# Altium schematic sheet ETH_3PORT.SchDoc
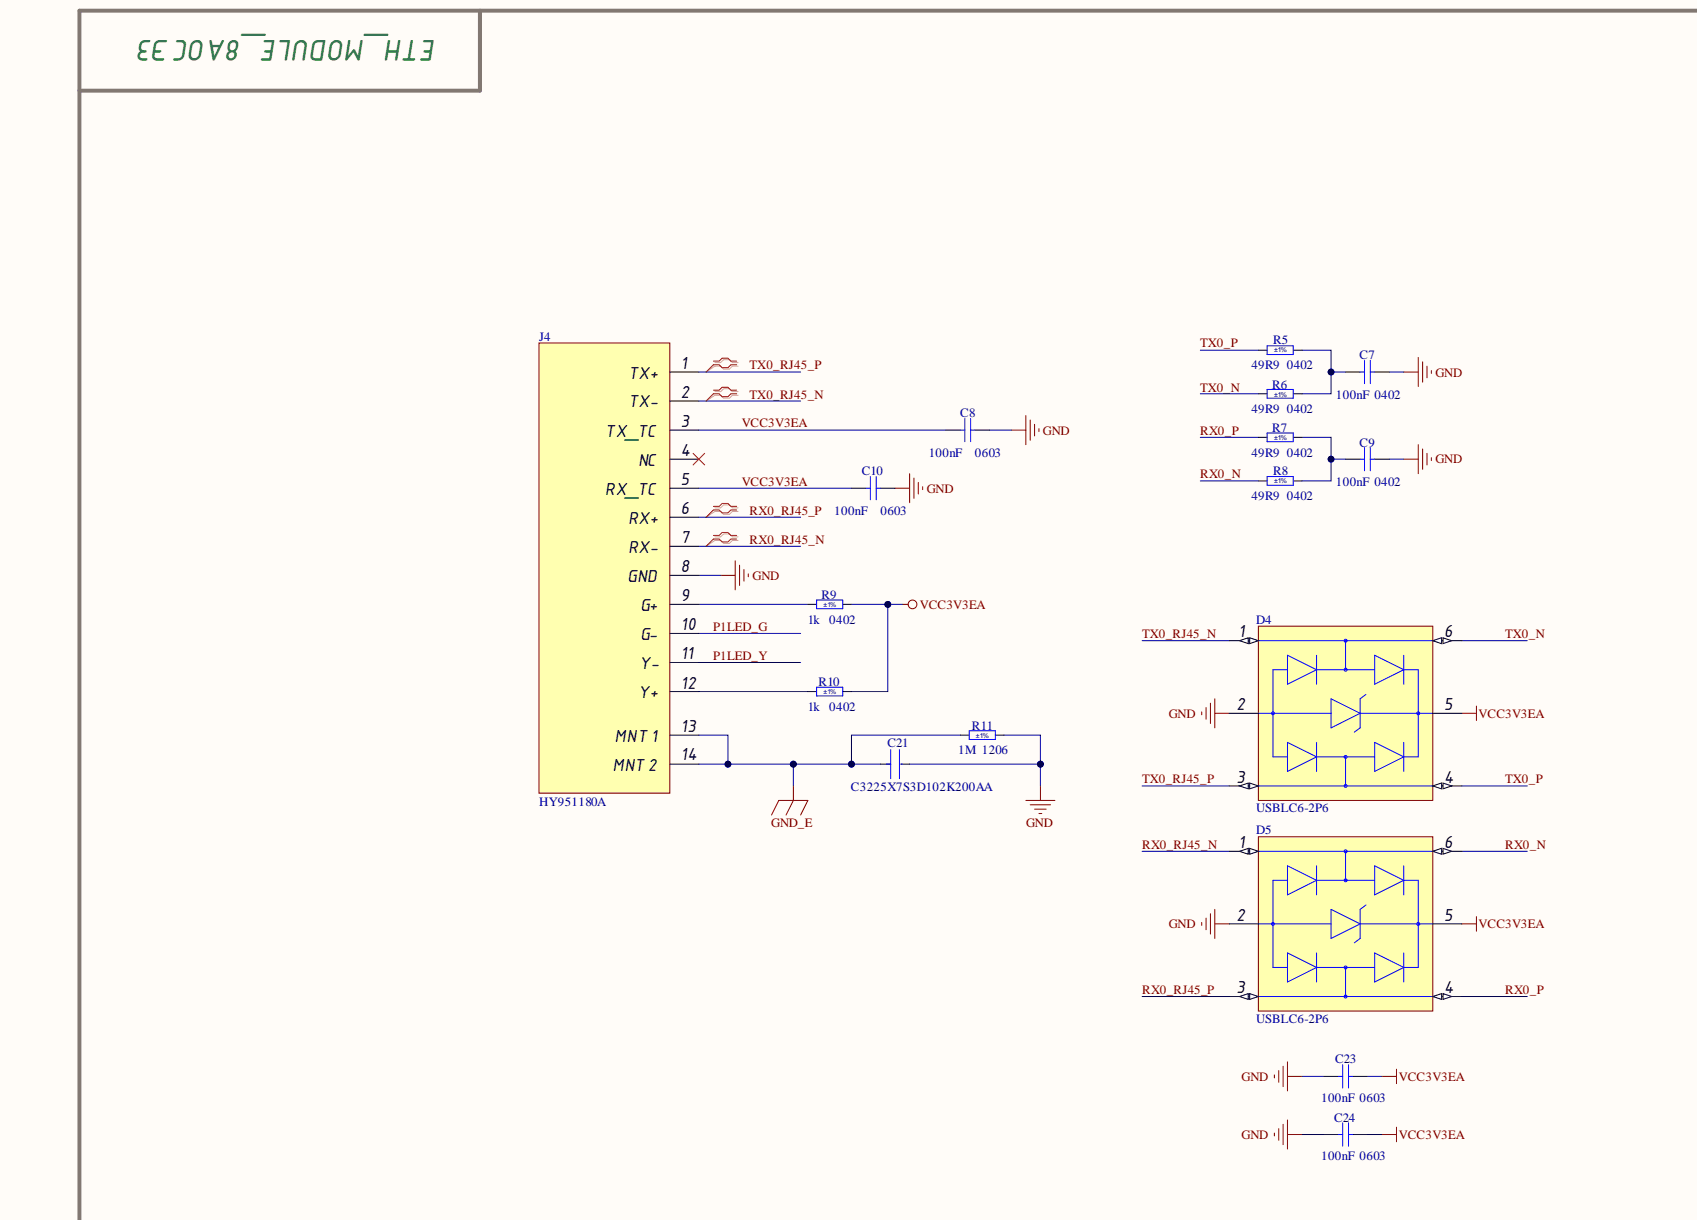
[diagram p0: ETH_3PORT.SchDoc, top left]
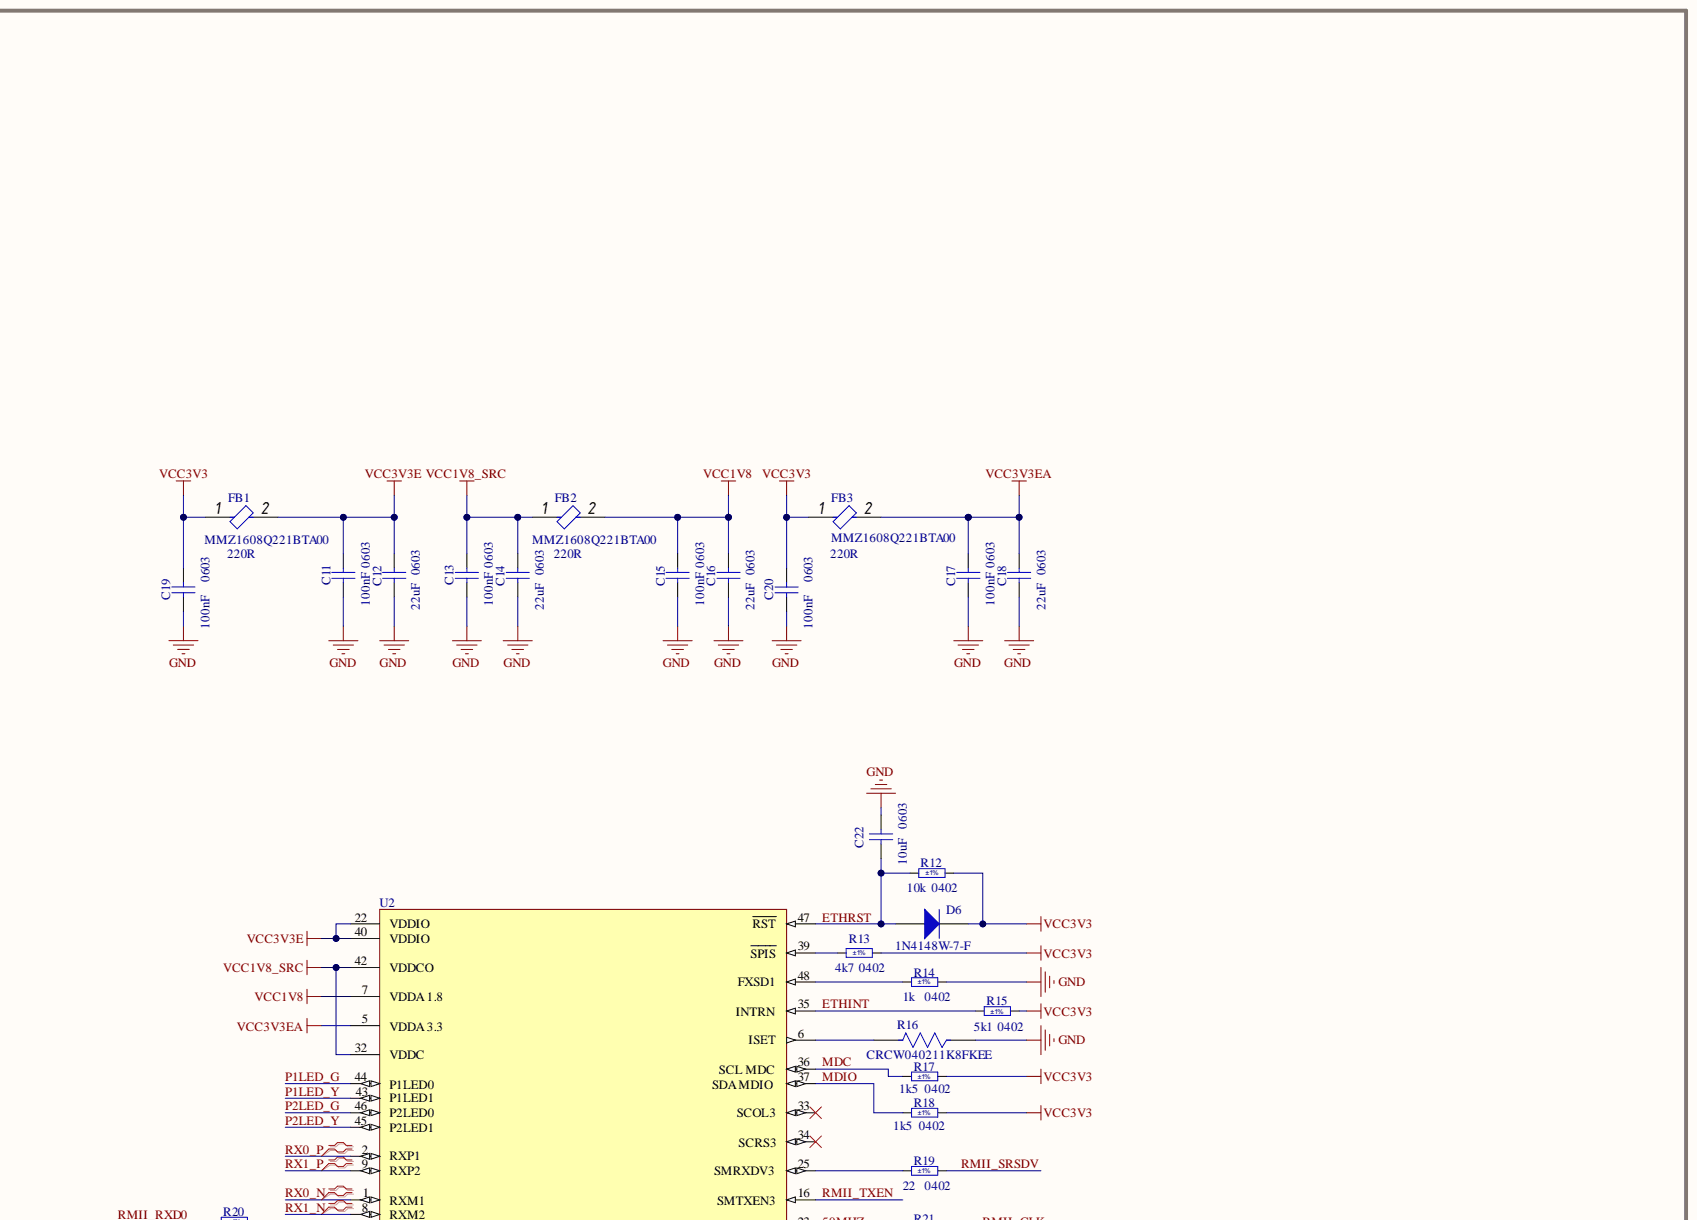
[diagram p1: ETH_3PORT.SchDoc, top right]
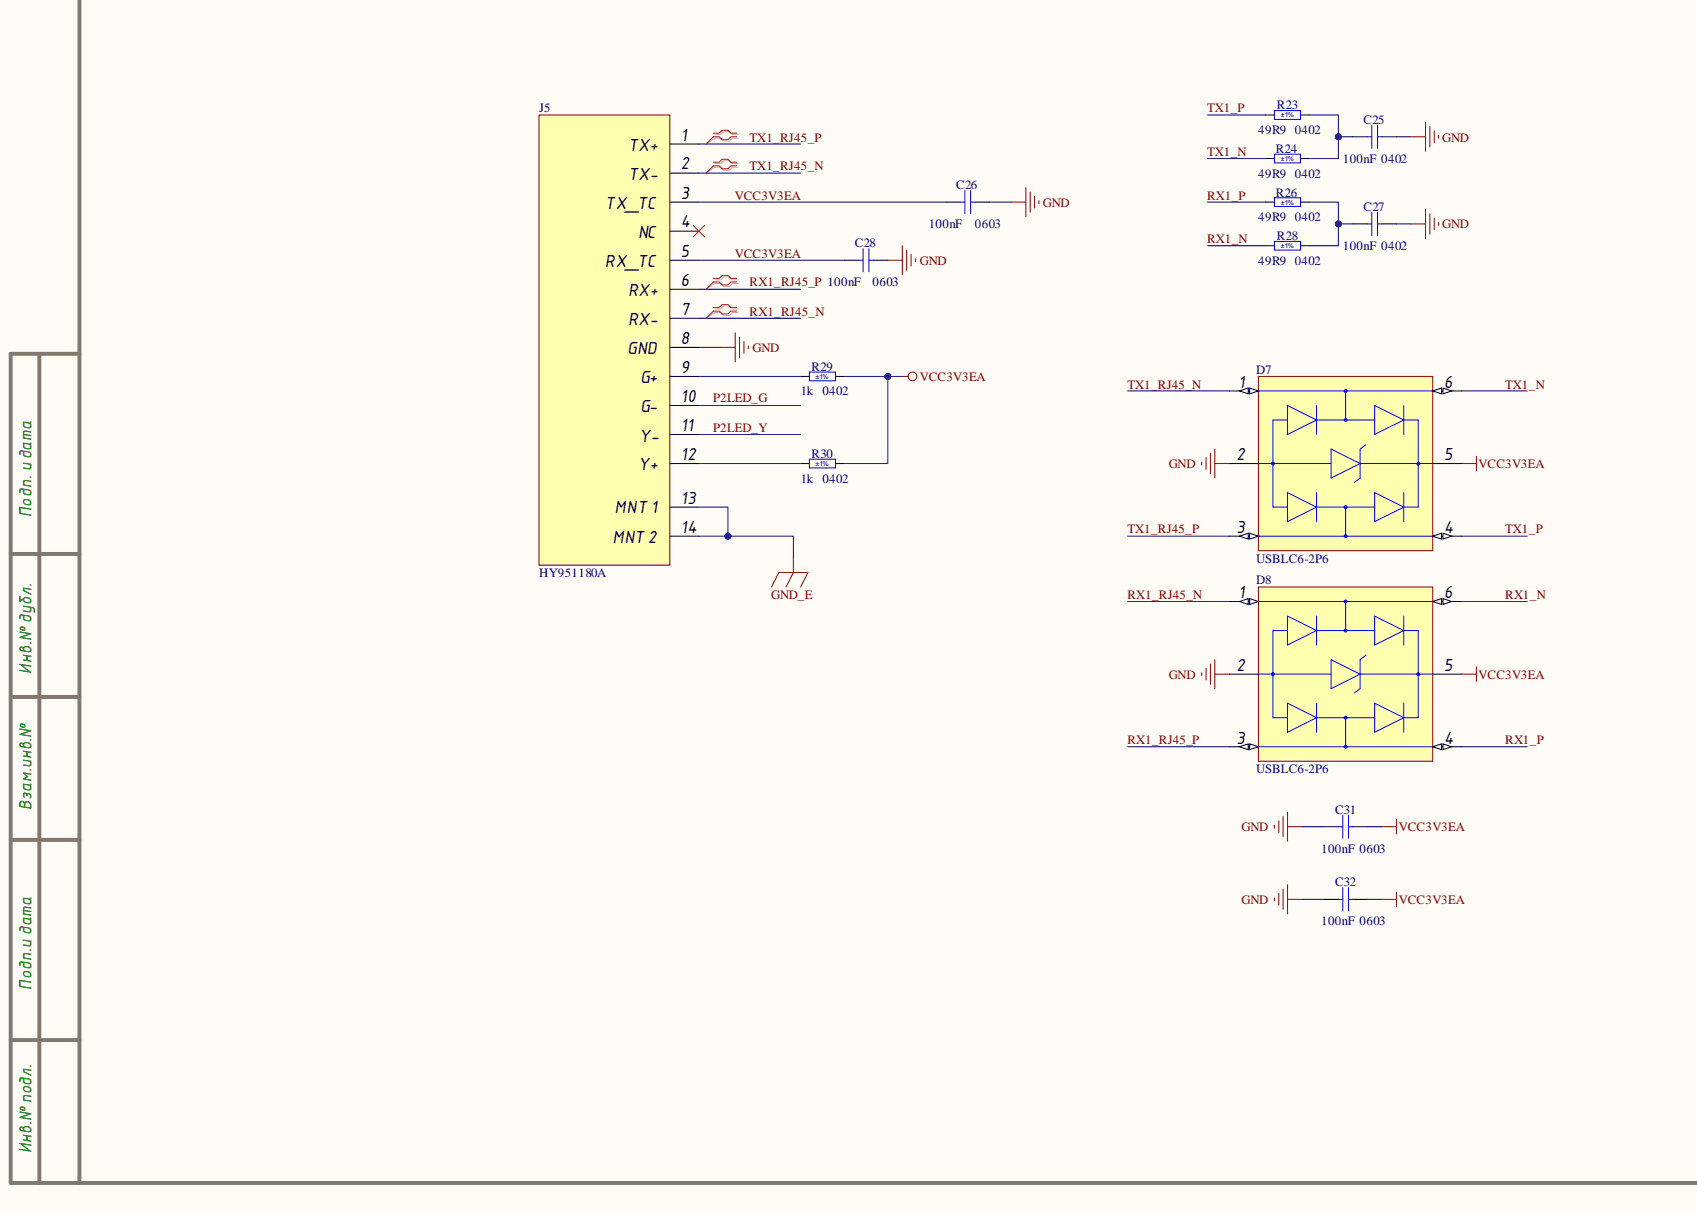
[diagram p2: ETH_3PORT.SchDoc, bottom left]
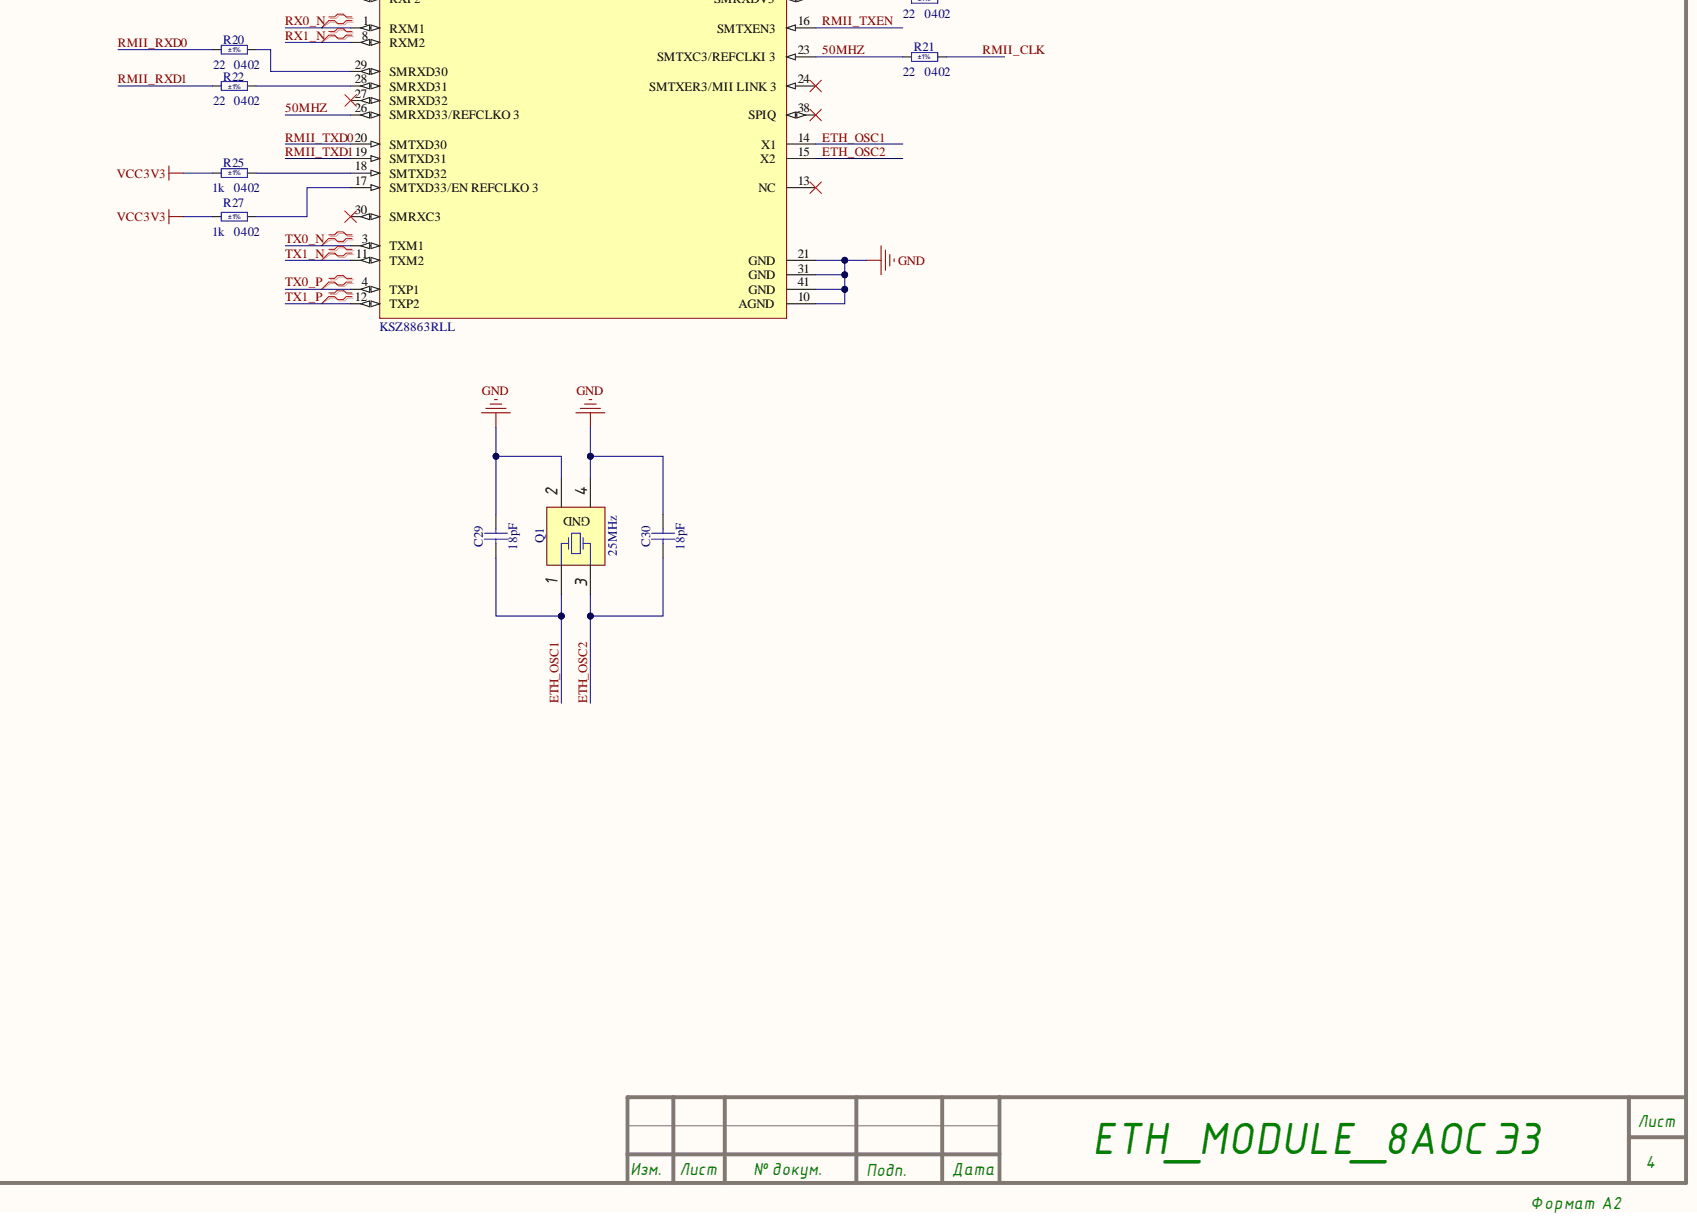
[diagram p3: ETH_3PORT.SchDoc, bottom right]

## Parts
C7  100nF  Case: 0402  [CAPC1005X55N]
C8  100nF  Case: 0603  [CAPC1608X90N]
C9  100nF  Case: 0402  [CAPC1005X55N]
C10  100nF  Case: 0603  [CAPC1608X90N]
C11  100nF  [CAPC1608X87N]
C12  22uF  Case: 0603  [CAPC1608X90N]
C13  100nF  Case: 0603  [CAPC1608X87N]
C14  22uF  Case: 0603  [CAPC1608X90N]
C15  100nF  Case: 0603  [CAPC1608X87N]
C16  22uF  Case: 0603  [CAPC1608X90N]
C17  100nF  Case: 0603  [CAPC1608X87N]
C18  22uF  Case: 0603  [CAPC1608X90N]
C19  100nF  Case: 0603  [CAPC1608X87N]
C20  100nF  Case: 0603  [CAPC1608X87N]
C21  C3225X7S3D102K200AA  [FP-C3225-200-0_4-IPC_B]
C22  10uF  Case: 0603  [CAPC1608X80N]
C23  100nF  Case: 0603  [CAPC1608X87N]
C24  100nF  Case: 0603  [CAPC1608X87N]
C25  100nF  Case: 0402  [CAPC1005X55N]
C26  100nF  Case: 0603  [CAPC1608X90N]
C27  100nF  Case: 0402  [CAPC1005X55N]
C28  100nF  Case: 0603  [CAPC1608X90N]
C29  18pF  [CAPC1608X87N]
C30  18pF  [CAPC1608X87N]
C31  100nF  Case: 0603  [CAPC1608X87N]
C32  100nF  Case: 0603  [CAPC1608X87N]
D4  USBLC6-2P6  [FP-SOT-666-MFG]
D5  USBLC6-2P6  [FP-SOT-666-MFG]
D6  1N4148W-7-F  [DIOD-SOD123-2_V]
D7  USBLC6-2P6  [FP-SOT-666-MFG]
D8  USBLC6-2P6  [FP-SOT-666-MFG]
FB1  MMZ1608Q221BTA00  Impedance: 220R  [FP-MMZ1608-0_8-IPC_C]
FB2  MMZ1608Q221BTA00  Impedance: 220R  [FP-MMZ1608-0_8-IPC_C]
FB3  MMZ1608Q221BTA00  Impedance: 220R  [FP-MMZ1608-0_8-IPC_C]
J4  HY951180A  [HY951180A]
J5  HY951180A  [HY951180A]
Q1  25MHz  [XTAL_EPSON_TSX-3225]
R5  49R9  Case: 0402  Tolerance: ±1%  [RESC1005X40N]
R6  49R9  Case: 0402  Tolerance: ±1%  [RESC1005X40N]
R7  49R9  Case: 0402  Tolerance: ±1%  [RESC1005X40N]
R8  49R9  Case: 0402  Tolerance: ±1%  [RESC1005X40N]
R9  1k  Case: 0402  Tolerance: ±1%  [RESC1005X40N]
R10  1k  Case: 0402  Tolerance: ±1%  [RESC1005X40N]
R11  1M  Case: 1206  Tolerance: ±1%  [RESC3216X65N]
R12  10k  Case: 0402  Tolerance: ±1%  [RESC1005X40N]
R13  4k7  Case: 0402  Tolerance: ±1%  [RESC1005X40N]
R14  1k  Case: 0402  Tolerance: ±1%  [RESC1005X40N]
R15  5k1  Case: 0402  Tolerance: ±1%  [RESC1005X40N]
R16  CRCW040211K8FKEE  [FP-CRCW0402-e3-IPC_B]
R17  1k5  Case: 0402  Tolerance: ±1%  [RESC1005X40N]
R18  1k5  Case: 0402  Tolerance: ±1%  [RESC1005X40N]
R19  22  Case: 0402  Tolerance: ±1%  [RESC1005X40N]
R20  22  Case: 0402  Tolerance: ±1%  [RESC1005X40N]
R21  22  Case: 0402  Tolerance: ±1%  [RESC1005X40N]
R22  22  Case: 0402  Tolerance: ±1%  [RESC1005X40N]
R23  49R9  Case: 0402  Tolerance: ±1%  [RESC1005X40N]
R24  49R9  Case: 0402  Tolerance: ±1%  [RESC1005X40N]
R25  1k  Case: 0402  Tolerance: ±1%  [RESC1005X40N]
R26  49R9  Case: 0402  Tolerance: ±1%  [RESC1005X40N]
R27  1k  Case: 0402  Tolerance: ±1%  [RESC1005X40N]
R28  49R9  Case: 0402  Tolerance: ±1%  [RESC1005X40N]
R29  1k  Case: 0402  Tolerance: ±1%  [RESC1005X40N]
R30  1k  Case: 0402  Tolerance: ±1%  [RESC1005X40N]
U2  KSZ8863RLL  [FP-LQFP7x7-48LD-PL-1-IPC_A]

## Nets
GND: C7.2 C8.2 C9.2 C10.2 C11.1 C12.1 C13.1 C14.1 C15.1 C16.1 C17.1 C18.1 C19.1 C20.1 C21.2 C22.1 C23.1 C24.1 C25.2 C26.2 C27.2 C28.2 C29.2 C30.2 C31.1 C32.1 D4.2 D5.2 D7.2 D8.2 J4.8(GND) J5.8(GND) Q1.2 Q1.4 R11.2 R14.2 R16.1 U2.10(AGND) U2.21(GND) U2.31(GND) U2.41(GND)
GND_E: C21.1 J4.13(MNT_1) J4.14(MNT_2) J5.13(MNT_1) J5.14(MNT_2) R11.1
VCC1V8: C15.2 C16.2 FB2.2 U2.7(VDDA_1.8)
VCC1V8_SRC: C13.2 C14.2 FB2.1 U2.32(VDDC) U2.42(VDDCO)
VCC3V3: C19.2 C20.2 D6.2 FB1.1 FB3.1 R12.2 R13.2 R15.2 R17.2 R18.2 R25.1 R27.1
VCC3V3E: C11.2 C12.2 FB1.2 U2.22(VDDIO) U2.40(VDDIO)
50MHZ: R21.1 U2.23(SMTXC3/REFCLKI_3) U2.26(SMRXD33/REFCLKO_3)
ETH_OSC1: C29.1 Q1.1 U2.14(X1)
ETH_OSC2: C30.1 Q1.3 U2.15(X2)
ETHINT: R15.1 U2.35(INTRN)
ETHRST: C22.2 D6.1 R12.1 U2.47(RST)
MDC: R17.1 U2.36(SCL_MDC)
MDIO: R18.1 U2.37(SDA_MDIO)
P1LED_G: J4.10(G-) U2.44(P1LED0)
P1LED_Y: J4.11(Y-) U2.43(P1LED1)
P2LED_G: J5.10(G-) U2.46(P2LED0)
P2LED_Y: J5.11(Y-) U2.45(P2LED1)
RMII_CLK: R21.2
RMII_RXD0: R20.1
RMII_RXD1: R22.1
RMII_SRSDV: R19.2
RMII_TXD0: U2.20(SMTXD30)
RMII_TXD1: U2.19(SMTXD31)
RMII_TXEN: U2.16(SMTXEN3)
RX0_N: D5.6 R8.1 U2.1(RXM1)
RX0_P: D5.4 R7.1 U2.2(RXP1)
RX0_RJ45_N: D5.1 J4.7(RX-)
RX0_RJ45_P: D5.3 J4.6(RX+)
RX1_N: D8.6 R28.1 U2.8(RXM2)
RX1_P: D8.4 R26.1 U2.9(RXP2)
RX1_RJ45_N: D8.1 J5.7(RX-)
RX1_RJ45_P: D8.3 J5.6(RX+)
TX0_N: D4.6 R6.1 U2.3(TXM1)
TX0_P: D4.4 R5.1 U2.4(TXP1)
TX0_RJ45_N: D4.1 J4.2(TX-)
TX0_RJ45_P: D4.3 J4.1(TX+)
TX1_N: D7.6 R24.1 U2.11(TXM2)
TX1_P: D7.4 R23.1 U2.12(TXP2)
TX1_RJ45_N: D7.1 J5.2(TX-)
TX1_RJ45_P: D7.3 J5.1(TX+)
VCC3V3EA: C8.1 C10.1 C17.2 C18.2 C23.2 C24.2 C26.1 C28.1 C31.2 C32.2 D4.5 D5.5 D7.5 D8.5 FB3.2 J4.3(TX_TC) J4.5(RX_TC) J5.3(TX_TC) J5.5(RX_TC) R9.2 R10.2 R29.2 R30.2 U2.5(VDDA_3.3)
NetC7_1: C7.1 R5.2 R6.2
NetC9_1: C9.1 R7.2 R8.2
NetC25_1: C25.1 R23.2 R24.2
NetC27_1: C27.1 R26.2 R28.2
NetJ4_9: J4.9(G+) R9.1
NetJ4_12: J4.12(Y+) R10.1
NetJ5_9: J5.9(G+) R29.1
NetJ5_12: J5.12(Y+) R30.1
NetR13_1: R13.1 U2.39(SPIS)
NetR14_1: R14.1 U2.48(FXSD1)
NetR16_2: R16.2 U2.6(ISET)
NetR19_1: R19.1 U2.25(SMRXDV3)
NetR20_2: R20.2 U2.29(SMRXD30)
NetR22_2: R22.2 U2.28(SMRXD31)
NetR25_2: R25.2 U2.18(SMTXD32)
NetR27_2: R27.2 U2.17(SMTXD33/EN_REFCLKO_3)

Not connected: J4 4(NC); J5 4(NC); U2 13(NC) 24(SMTXER3/MII_LINK_3) 27(SMRXD32) 30(SMRXC3) 33(SCOL3) 34(SCRS3) 38(SPIQ)

## Library data of the parts
C7 C9 C25 C27 = CC0402_100NF_16V_10%_X5R: Non-polarised capacitor; Manufacturer: GENERIC; Manufacturer1 Part Number: GRM155R61C104KA88D; Part Description: SMD Multilayer Chip Ceramic Capacitor; Database Table Name: Capacitors SMD; TC: X5R; Tolerance: ±10%; Voltage: 16V; Component Kind: Standard; Component Type: Standard; CreateDate: 10.12.2013; HelpURL: \\cern.ch\dfs\Applications\Altium\Datasheets\CC0402_X5R_MURATA_GRM.pdf; LatestRevisionDate: 10.12.2013; Library Name: Capacitors.DbLib; Manufacturer1 ComponentHeight: 0.55mm; Manufacturer1 Example: MURATA; Manufacturer Part Number: CC0402_100NF_16V_10%_X5R; Mounted: Yes; Part Number: CC0402_100NF_16V_10%_X5R; Pin Count: 2; Sense: No; SMD: Yes; Socket: No; Status: None; Value: 100nF; Author: CERN DEM JLC
C8 C10 C26 C28 = CC0603_100NF_25V_20%_X5R_TDK_CGA3E2X5R1E104M080: Non-polarised capacitor; Manufacturer: TDK; Part Description: SMD Multilayer Chip Ceramic Capacitor (Automotive Grade); Database Table Name: Capacitors SMD; TC: X5R; Tolerance: ±20%; Voltage: 25V; Component Kind: Standard; Component Type: Standard; ComponentHeight: 0.9mm; CreateDate: 01.07.2020; HelpURL: \\cern.ch\dfs\Applications\Altium\Datasheets\CC0603_X5R_TDK_CGA(Up to 75V).pdf; LatestRevisionDate: 01.07.2020; Library Name: Capacitors.DbLib; Manufacturer Part Number: CGA3E2X5R1E104M080; Mounted: Yes; Part Number: CC0603_100NF_25V_20%_X5R_TDK_CGA3E2X5R1E104M080; Pin Count: 2; Sense: No; SMD: Yes; Socket: No; Status: Not Recommended; Value: 100nF; Author: CERN DEM BC
C11 C13 C15 C17 C19 C20 = CC0603_100NF_50V_10%_X5R: Non-polarised capacitor; Manufacturer: GENERIC; Manufacturer1 Part Number: C1608X5R1H104K080; Part Description: SMD Multilayer Chip Ceramic Capacitor; Database Table Name: Capacitors SMD; TC: X5R; Tolerance: ±10%; Voltage: 50V; Component Kind: Standard; Component Type: Standard; CreateDate: 08.01.2016; HelpURL: \\cern.ch\dfs\Applications\Altium\Datasheets\CC0603_X5R_TDK_C.pdf; LatestRevisionDate: 08.01.2016; Library Name: Capacitors.DbLib; Manufacturer1 ComponentHeight: 0.9mm; Manufacturer1 Example: TDK; Manufacturer Part Number: CC0603_100NF_50V_10%_X5R; Mounted: Yes; Part Number: CC0603_100NF_50V_10%_X5R; Pin Count: 2; Sense: No; SMD: Yes; Socket: No; Status: None; Value: 100nF; Author: CERN DEM MR
  C11: Case: 0603
C12 C14 C16 C18 = CC0603_22UF_6.3V_20%_X5R: Non-polarised capacitor; Manufacturer: GENERIC; Manufacturer1 Part Number: C1608X5R0J226M080; Part Description: SMD Multilayer Chip Ceramic Capacitor; Database Table Name: Capacitors SMD; TC: X5R; Tolerance: ±20%; Voltage: 6.3V; Component Kind: Standard; Component Type: Standard; CreateDate: 16.12.2013; HelpURL: \\cern.ch\dfs\Applications\Altium\Datasheets\CC0603_X5R_TDK_C.pdf; LatestRevisionDate: 03.12.2020; Library Name: Capacitors.DbLib; Manufacturer1 ComponentHeight: 0.9mm; Manufacturer1 Example: TDK; Manufacturer Part Number: CC0603_22UF_6.3V_20%_X5R; Mounted: Yes; Part Number: CC0603_22UF_6.3V_20%_X5R; Pin Count: 2; Sense: No; SMD: Yes; Socket: No; Status: None; Value: 22uF; Author: CERN DEM JLC
C21 = CMP-08246-003296-1: Multilayer Ceramic Capacitors 1nF ±10% 2000V X7S SMD 1210; Voltage Rating: 2kV; Capacitance: 1nF; Case Code (Imperial): 1210; Case Code (Metric): 3225; Case/Package: 1210; Component Kind: Standard; Depth: 2.5mm; Dielectric: X7S; Length: 0.126inch; Library Name: Altium Content Vault; Max Operating Temperature: 125°C; Min Operating Temperature: -55°C; Mount: Surface Mount; Package Quantity: 1; Packaging: Tape and Reel; REACH SVHC: No SVHC; Resistor Type: Ceramic; RoHS Compliant: Yes; Series: C; Termination: SMD/SMT; Thickness: 0.087inch; Tolerance: 10%; Voltage Rating (DC): 2kV; Weight: 0.000571oz; Width: 0.098inch
C22 = CC0603_10UF_10V_10%_X5R: Non-polarised capacitor; Manufacturer: GENERIC; Manufacturer1 Part Number: GRM188R61A106KE69D; Part Description: SMD Multilayer Chip Ceramic Capacitor; Database Table Name: Capacitors SMD; TC: X5R; Tolerance: ±10%; Voltage: 10V; Component Kind: Standard; Component Type: Standard; CreateDate: 31.01.2017; HelpURL: \\cern.ch\dfs\Applications\Altium\Datasheets\CC0603_MURATA_GRM188R61A106KE69D.pdf; LatestRevisionDate: 31.01.2017; Library Name: Capacitors.DbLib; Manufacturer1 ComponentHeight: 0.8mm; Manufacturer1 Example: MURATA; Manufacturer Part Number: CC0603_10UF_10V_10%_X5R; Mounted: Yes; Part Number: CC0603_10UF_10V_10%_X5R; Pin Count: 2; Sense: No; SMD: Yes; Socket: No; Status: None; Value: 10uF; Author: CERN DEM JLC
C23 C24 C31 C32 = CC0603_100NF_50V_10%_X7R: Non-polarised capacitor; Manufacturer: GENERIC; Manufacturer1 Part Number: 223858615649; Part Description: SMD Multilayer Chip Ceramic Capacitor; Database Table Name: Capacitors SMD; TC: X7R; Tolerance: ±10%; Voltage: 50V; Component Kind: Standard; Component Type: Standard; CreateDate: 03.12.2007; HelpURL: \\cern.ch\dfs\Applications\Altium\Datasheets\CC0603_X7R_PHYCOMP.pdf; LatestRevisionDate: 03.12.2007; Library Name: Capacitors.DbLib; Manufacturer1 ComponentHeight: 0.87mm; Manufacturer1 Example: PHYCOMP; Manufacturer Part Number: CC0603_100NF_50V_10%_X7R; Mounted: Yes; Part Number: CC0603_100NF_50V_10%_X7R; Pin Count: 2; Sense: No; SMD: Yes; Socket: No; Status: Preferred; Value: 100nF; Author: CERN DEM JLC
C29 C30 = CC0603_18PF_50V_5%_NP0: Non-polarised capacitor; Manufacturer: GENERIC; Manufacturer1 Part Number: 223886715189; Part Description: SMD Multilayer Chip Ceramic Capacitor; Database Table Name: Capacitors SMD; TC: C0G/NP0; Tolerance: ±5%; Voltage: 50V; Case: 0603; Component Kind: Standard; Component Type: Standard; CreateDate: 03.12.2007; HelpURL: \\cern.ch\dfs\Applications\Altium\Datasheets\CC0603_NP0_PHYCOMP.pdf; LatestRevisionDate: 03.12.2007; Library Name: Capacitors.DbLib; Manufacturer1 ComponentHeight: 0.87mm; Manufacturer1 Example: PHYCOMP; Manufacturer Part Number: CC0603_18PF_50V_5%_NP0; Mounted: Yes; Part Number: CC0603_18PF_50V_5%_NP0; Pin Count: 2; Sense: No; SMD: Yes; Socket: No; Status: Preferred; Value: 18pF; Author: CERN DEM JLC
D4 D5 D7 D8 = CMP-12225-000058-1: TVS DIODE 5.25V 17V SOT666; Breakdown Voltage: 6V; Capacitance: 3.5pF; Direction: Unidirectional; Element Configuration: Dual; ESD Protection: Yes; Leakage Current: 150nA; Number of Bidirectional Channels: 2; Number of Elements: 1; Operating Supply Voltage: 5V; Peak Pulse Current: 5A; Test Current: 1mA; Case/Package: SOT-666; Clamping Voltage: 17V; Component Kind: Standard; Contact Plating: Tin; Depth: 1.3mm; Height: 0.6mm; Lead Free: Lead Free; Length: 1.7mm; Library Name: Altium Content Vault; Max Breakdown Voltage: 6V; Max Operating Temperature: 125°C; Max Reverse Leakage Current: 150nA; Min Breakdown Voltage: 6V; Min Operating Temperature: -40°C; Mount: Surface Mount; Number of Channels: 2; Number of Pins: 6; Package Quantity: 1; Packaging: Tape and Reel; Polarity: Unidirectional; Power Line Protection: Yes; Radiation Hardening: No; REACH SVHC: No SVHC; Reverse Breakdown Voltage: 6V; Reverse Standoff Voltage: 5V; Termination: SMD/SMT; Width: 1.3mm; Working Voltage: 5V
D6 = CMP-2000-04932-2: Fast Switching Diode, 100 V, 0.15 A, -65 to 150 degC, 2-Pin?SOD123, RoHS, Tape and Reel; Brand: DiodesIncorporated; Configuration: Single; If - Forward Current: 0.15A; Ir - Reverse Current: 1uA; Max Surge Current: 2A; Peak Reverse Voltage: 100V; Recovery Time: 4ns; Unit Weight: 0.000353oz; Vf - Forward Voltage: 1.25V; Component Kind: Standard; Datasheet URL: http://www.farnell.com/datasheets/1915529.pdf; Datasheet Version: Rev. 24-2, 10/2013; Factory Pack Quantity: 3000; Height: 1.25mm; Length: 2.85mm; Library Name: Altium Content Vault; Manufacturer: DiodesIncorporated; Manufacturer URL: http://www.diodes.com/; Maximum Operating Temperature: +150C; Minimum Operating Temperature: -65C; Mounting Style: SMD/SMT; Operating Temperature Range: -65Cto+150C; Package / Case: SOD-123; Package Reference: SOD123-2; Package URL: http://www.diodes.com/datasheets/ds18004.pdf; Packaging: Reel; Product: SwitchingDiodes; Product Category: Diodes-GeneralPurpose,Power,Switching; RoHS: True; Series: 1N4148; Type: SwitchingDiode; Width: 1.7mm
FB1-FB3 = CMP-08257-000459-1: Signal Line Ferrite Bead 220Ω  @ 100MHz 500mA DCR 400mΩ SMD 0603; DC Resistance (DCR): 400mR; Tolerance: 25%; Case Code (Imperial): 0603; Case Code (Metric): 1608; Case/Package: 0603; Component Kind: Standard; Core Material: Ferrite; Current Rating: 500mA; Height: 0.037inch; Length: 0.063inch; Library Name: Altium Content Vault; Max DC Current: 500mA; Mount: Surface Mount; Package Quantity: 4000; Packaging: Tape and Reel; RoHS Compliant: Yes; Width: 0.031inch
J4 J5 = HY951180A: 1x1 Port Tab upright RJ45 Connector with Integrated Magnetics and LED for 10/100Base-TX NIC Applications; Manufacturer: TE Connectivity; Manufacturer Part Number: 5-2301995-3; Conn Gender: Female; Conn Orientation: Vertical; Is Female: yes; Number Of Contacts: 8; Pitch: 1.27um; Shielding: true; Automotive: No; Category: Conn; Component Kind: Standard; ComponentLink2Description: Datasheet; ComponentLink2URL: https://www.te.com/global-en/product-5-2301995-3.html; Contact Material: Gold,Nickel; Device Class L1: Connectors; Device Class L2: Modular / Ethernet Connectors; Device Class L3: unset; Digikey Description: CONN MAGJACK 1PORT 100 BASE-T; Height: 17.15mm; Lead Free: Yes; Library Name: Schlib.SchLib; Octopart: https://octopart.com/5-2301995-3-te+connectivity-84771741; Package: 5-2301995-3; RoHS: TRUE; Temperature: -40°C to +85°C; Temperature Range High: +85°C; Temperature Range Low: -40°C
Q1 = XTAL_25MHZ_EPSON_TSX-3225 25.0000MF10Z-AC: Crystal 4 pins 1=XTAL Control 2=GND3=XTAL 4=GND; Manufacturer: EPSON; Part Description: Tolerance: ±10ppm,  Stability:±10ppm, -20°C to 75°C, 9pF, Surface Mount Quartz Crystal, TX-3225 Series; Bonding: No; Database Table Name: Crystals & Oscillators; PressFit: No; Component Kind: Standard; Component Type: Standard; ComponentHeight: 0.6mm; ComponentLink1Description: Ordering Informations; ComponentLink1URL: \\cern.ch\dfs\Applications\Altium\Datasheets\XTAL_EPSON_TSX-3225_Ordering-Informations.pdf; CreateDate: 03.04.2012; HelpURL: \\cern.ch\dfs\Applications\Altium\Datasheets\XTAL_EPSON_TSX-3225.pdf; LatestRevisionDate: 06.10.2016; Library Name: ICs And Semiconductors.DbLib; Manufacturer Part Number: TSX-3225 25.0000MF10Z-AC; Mounted: Yes; PackageDescription: Crystal, Corner Concave; 4 Pin, 2.50mm L X 3.20mm W X 0.6mm H, TSX-3225 Serie; Part Number: XTAL_25MHZ_EPSON_TSX-3225 25.0000MF10Z-AC; Pin Count: 4; Sense: No; SMD: Yes; Socket: No; Value: 25MHz; Author: CERN DEM JLC
R5-R8 R23 R24 R26 R28 = R0402_49R9_1%_0.0625W_100PPM: Resistor - 1%; Manufacturer: GENERIC; Manufacturer1 Part Number: 232270674999L or RC0402FR-0749R9L; Part Description: General Purpose Thick Film Chip Resistor; Database Table Name: Resistors SMD; PressFit: No; TC: ±100ppm/°C; Component Kind: Standard; Component Type: Standard; CreateDate: 24.10.2012; HelpURL: \\cern.ch\dfs\Applications\Altium\Datasheets\R0402_Phycomp_RC0402.pdf; LatestRevisionDate: 24.10.2012; Library Name: Resistors.DbLib; Manufacturer1 ComponentHeight: 0.4mm; Manufacturer1 Example: YAGEO PHYCOMP; Manufacturer Part Number: R0402_49R9_1%_0.0625W_100PPM; Mounted: Yes; Part Number: R0402_49R9_1%_0.0625W_100PPM; Pin Count: 2; Power: 0.0625W; Sense: No; SMD: Yes; Socket: No; Status: Not Recommended; Value: 49R9; Author: CERN DEM JLC
R9 R10 R14 R25 R27 R29 R30 = R0402_1K_1%_0.0625W_100PPM: Resistor - 1%; Manufacturer: GENERIC; Manufacturer1 Part Number: 232270671002L; Part Description: General Purpose Thick Film Chip Resistor; Database Table Name: Resistors SMD; PressFit: No; TC: ±100ppm/°C; Component Kind: Standard; Component Type: Standard; CreateDate: 03.12.2007; HelpURL: \\cern.ch\dfs\Applications\Altium\Datasheets\R0402_Phycomp_RC0402.pdf; LatestRevisionDate: 17.10.2012; Library Name: Resistors.DbLib; Manufacturer1 ComponentHeight: 0.4mm; Manufacturer1 Example: YAGEO PHYCOMP; Manufacturer Part Number: R0402_1K_1%_0.0625W_100PPM; Mounted: Yes; Part Number: R0402_1K_1%_0.0625W_100PPM; Pin Count: 2; Power: 0.0625W; Sense: No; SMD: Yes; Socket: No; Status: Not Recommended; Value: 1k; Author: CERN DEM JLC
R11 = R1206_1M_1%_0.25W_100PPM: Resistor - 1%; Manufacturer: GENERIC; Manufacturer1 Part Number: NRC12F1004TRF; Part Description: General Purpose Thick Film Chip Resistor; Database Table Name: Resistors SMD; Power: 0.25W; PressFit: No; TC: ±100ppm/°C; Component Kind: Standard; Component Type: Standard; CreateDate: 03.12.2007; HelpURL: \\cern.ch\dfs\Applications\Altium\Datasheets\R1206_NIC_NRC.pdf; LatestRevisionDate: 13.03.2008; Library Name: Resistors.DbLib; Manufacturer1 ComponentHeight: 0.65mm; Manufacturer1 Example: NIC COMPONENT; Manufacturer Part Number: R1206_1M_1%_0.25W_100PPM; Mounted: Yes; Part Number: R1206_1M_1%_0.25W_100PPM; Pin Count: 2; Sense: No; SMD: Yes; Socket: No; Status: Preferred; Value: 1M; Author: CERN DEM JLC
R12 = R0402_10K_1%_0.0625W_100PPM: Resistor - 1%; Manufacturer: GENERIC; Manufacturer1 Part Number: 232270671003L; Part Description: General Purpose Thick Film Chip Resistor; Database Table Name: Resistors SMD; PressFit: No; TC: ±100ppm/°C; Component Kind: Standard; Component Type: Standard; CreateDate: 03.12.2007; HelpURL: \\cern.ch\dfs\Applications\Altium\Datasheets\R0402_Phycomp_RC0402.pdf; LatestRevisionDate: 17.10.2012; Library Name: Resistors.DbLib; Manufacturer1 ComponentHeight: 0.4mm; Manufacturer1 Example: YAGEO PHYCOMP; Manufacturer Part Number: R0402_10K_1%_0.0625W_100PPM; Mounted: Yes; Part Number: R0402_10K_1%_0.0625W_100PPM; Pin Count: 2; Power: 0.0625W; Sense: No; SMD: Yes; Socket: No; Status: Not Recommended; Value: 10k; Author: CERN DEM JLC
R13 = R0402_4K7_1%_0.0625W_100PPM: Resistor - 1%; Manufacturer: GENERIC; Manufacturer1 Part Number: 232270674702L; Part Description: General Purpose Thick Film Chip Resistor; Database Table Name: Resistors SMD; PressFit: No; TC: ±100ppm/°C; Component Kind: Standard; Component Type: Standard; CreateDate: 03.12.2007; HelpURL: \\cern.ch\dfs\Applications\Altium\Datasheets\R0402_Phycomp_RC0402.pdf; LatestRevisionDate: 17.10.2012; Library Name: Resistors.DbLib; Manufacturer1 ComponentHeight: 0.4mm; Manufacturer1 Example: YAGEO PHYCOMP; Manufacturer Part Number: R0402_4K7_1%_0.0625W_100PPM; Mounted: Yes; Part Number: R0402_4K7_1%_0.0625W_100PPM; Pin Count: 2; Power: 0.0625W; Sense: No; SMD: Yes; Socket: No; Status: Not Recommended; Value: 4k7; Author: CERN DEM JLC
R15 = R0402_5K1_1%_0.0625W_100PPM: Resistor - 1%; Manufacturer: GENERIC; Manufacturer1 Part Number: 232270675102L; Part Description: General Purpose Thick Film Chip Resistor; Database Table Name: Resistors SMD; PressFit: No; TC: ±100ppm/°C; Component Kind: Standard; Component Type: Standard; CreateDate: 03.12.2007; HelpURL: \\cern.ch\dfs\Applications\Altium\Datasheets\R0402_Phycomp_RC0402.pdf; LatestRevisionDate: 17.10.2012; Library Name: Resistors.DbLib; Manufacturer1 ComponentHeight: 0.4mm; Manufacturer1 Example: YAGEO PHYCOMP; Manufacturer Part Number: R0402_5K1_1%_0.0625W_100PPM; Mounted: Yes; Part Number: R0402_5K1_1%_0.0625W_100PPM; Pin Count: 2; Power: 0.0625W; Sense: No; SMD: Yes; Socket: No; Status: Not Recommended; Value: 5k1; Author: CERN DEM JLC
R16 = CMP-02407-007623-1: RES Thick Film, 11.8kΩ, 1%, 0.063W, 100ppm/°C, 0402; Max Power Dissipation: 63mW; Resistance: 11.8kR; Case/Package: 0402; Component Kind: Standard; Composition: Thick Film; Contact Plating: Tin; Features: Automotive AEC-Q200; Height: 0.016inch; Lead Free: Lead Free; Length: 0.039inch; Library Name: Altium Content Vault; Max Operating Temperature: 155°C; Min Operating Temperature: -55°C; Number of Terminations: 2; Package Quantity: 50000; Packaging: Tape and Reel; Radiation Hardening: No; Temperature Coefficient: 100ppm/°C; Tolerance: 1%; Width: 0.02inch
R17 R18 = R0402_1K5_1%_0.0625W_100PPM: Resistor - 1%; Manufacturer: GENERIC; Manufacturer1 Part Number: 232270671502L; Part Description: General Purpose Thick Film Chip Resistor; Database Table Name: Resistors SMD; PressFit: No; TC: ±100ppm/°C; Component Kind: Standard; Component Type: Standard; CreateDate: 03.12.2007; HelpURL: \\cern.ch\dfs\Applications\Altium\Datasheets\R0402_Phycomp_RC0402.pdf; LatestRevisionDate: 17.10.2012; Library Name: Resistors.DbLib; Manufacturer1 ComponentHeight: 0.4mm; Manufacturer1 Example: YAGEO PHYCOMP; Manufacturer Part Number: R0402_1K5_1%_0.0625W_100PPM; Mounted: Yes; Part Number: R0402_1K5_1%_0.0625W_100PPM; Pin Count: 2; Power: 0.0625W; Sense: No; SMD: Yes; Socket: No; Status: Not Recommended; Value: 1k5; Author: CERN DEM JLC
R19-R22 = R0402_22R_1%_0.0625W_100PPM: Resistor - 1%; Manufacturer: GENERIC; Manufacturer1 Part Number: 232270672209L; Part Description: General Purpose Thick Film Chip Resistor; Database Table Name: Resistors SMD; PressFit: No; TC: ±100ppm/°C; Component Kind: Standard; Component Type: Standard; CreateDate: 03.12.2007; HelpURL: \\cern.ch\dfs\Applications\Altium\Datasheets\R0402_Phycomp_RC0402.pdf; LatestRevisionDate: 17.10.2012; Library Name: Resistors.DbLib; Manufacturer1 ComponentHeight: 0.4mm; Manufacturer1 Example: YAGEO PHYCOMP; Manufacturer Part Number: R0402_22R_1%_0.0625W_100PPM; Mounted: Yes; Part Number: R0402_22R_1%_0.0625W_100PPM; Pin Count: 2; Power: 0.0625W; Sense: No; SMD: Yes; Socket: No; Status: Not Recommended; Value: 22; Author: CERN DEM JLC
U2 = CMP-06281-000038-1: IC ETHERNET SW 3PORT 48-LQFP; Data Rate: 100Mbps; Interface: I2C; Max Supply Current: 112mA; Max Supply Voltage: 3.465V; Min Supply Voltage: 1.71V; Nominal Supply Current: 85mA; Number of Functions: 3; Number of Transceivers: 2; Operating Supply Voltage: 3.3V; Case/Package: LQFP; Component Kind: Standard; Height: 1.4mm; Lead Free: Lead Free; Length: 7mm; Library Name: Altium Content Vault; Max Operating Temperature: 70°C; Min Operating Temperature: 0°C; Mount: Surface Mount; Number of Pins: 48; Number of Ports: 3; Package Quantity: 250; Packaging: Tray; Radiation Hardening: No; REACH SVHC: No SVHC; Series: -; Supply Current: 85mA; Supply Voltage-Nom: 3.3V; Width: 7mm
